# Altium schematic sheet sensors.SchDoc
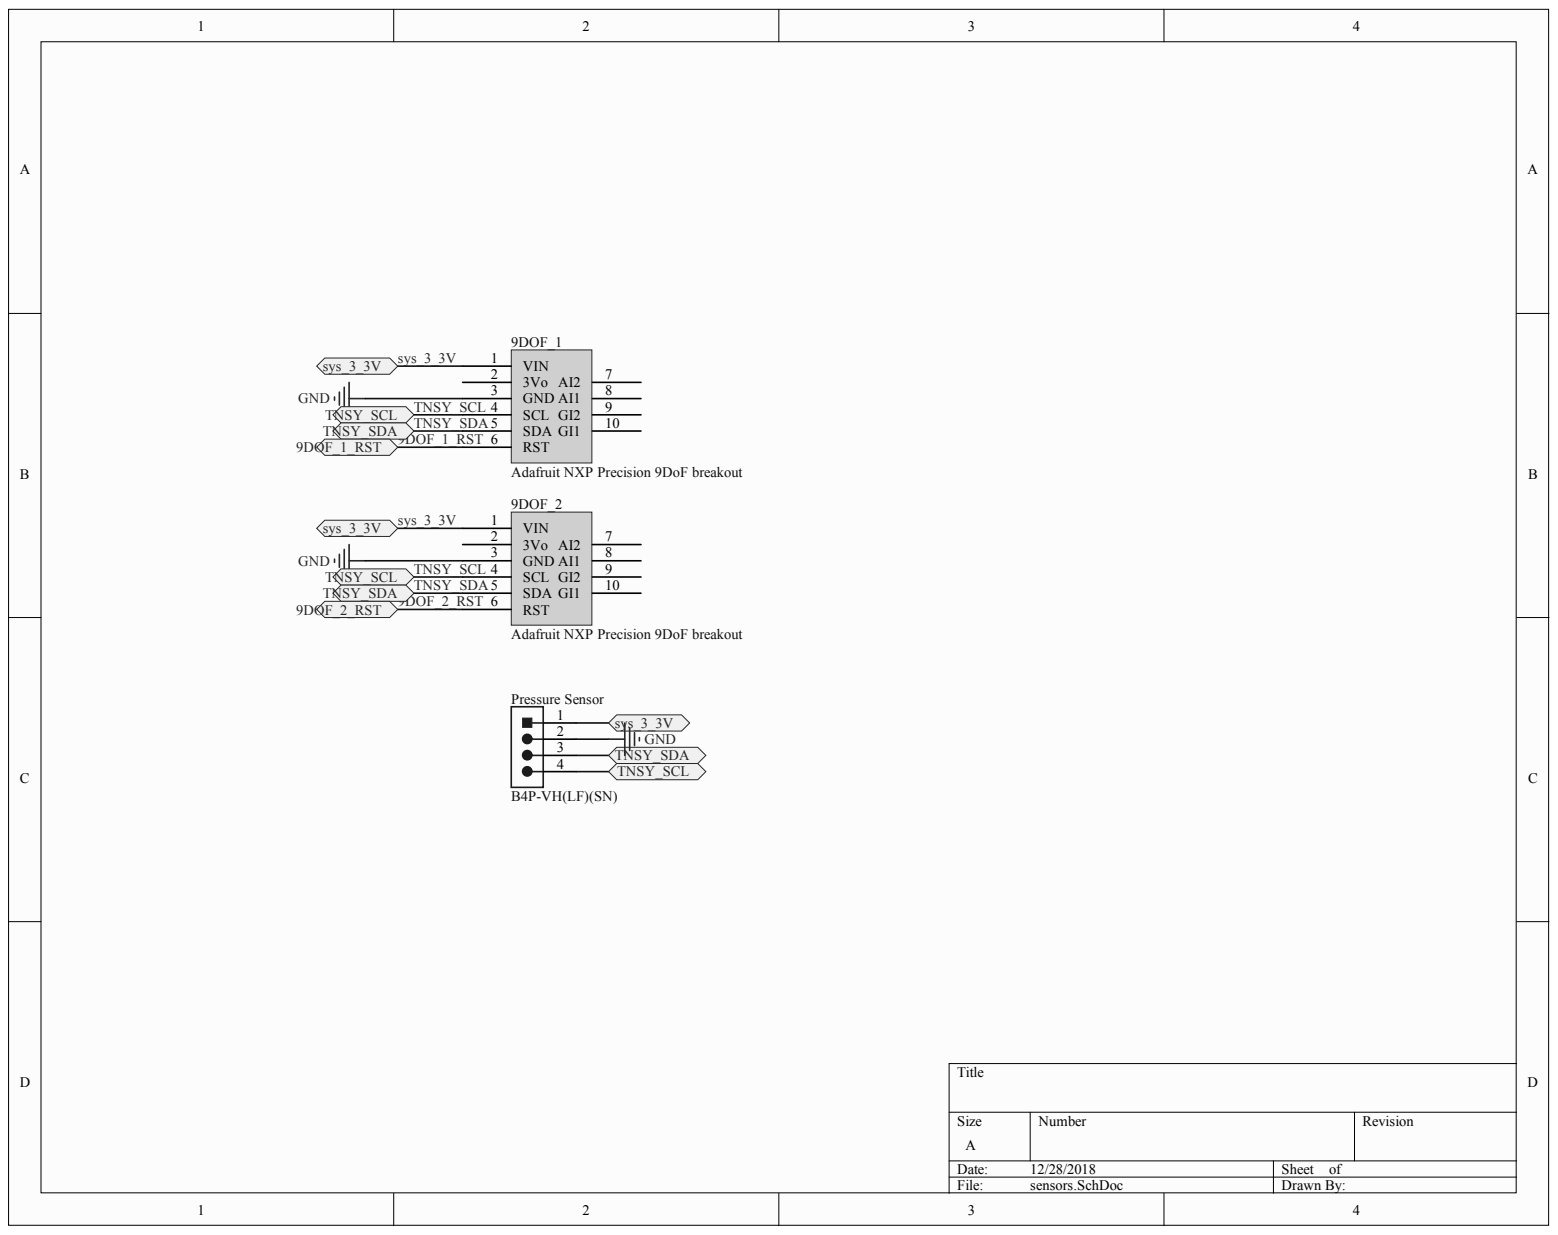
[diagram p0: sensors.SchDoc]

## Parts
9DOF_1  Adafruit NXP Precision 9DoF breakout  [PCB-qycbgvnmg1jf60fg22jb-1]
9DOF_2  Adafruit NXP Precision 9DoF breakout  [PCB-qycbgvnmg1jf60fg22jb-1]
Pressure_Sensor  B4P-VH(LF)(SN)  [PCB-643000-1]

## Ports
9DOF_1_RST, 9DOF_2_RST, SYS_3_3V, TNSY_SCL, TNSY_SDA

## Nets
GND: 9DOF_1.3(GND) 9DOF_2.3(GND) Pressure_Sensor.2
9DOF_1_RST: 9DOF_1.6(RST) ; port 9DOF_1_RST
9DOF_2_RST: 9DOF_2.6(RST) ; port 9DOF_2_RST
SYS_3_3V: 9DOF_1.1(VIN) 9DOF_2.1(VIN) Pressure_Sensor.1 ; port SYS_3_3V
TNSY_SCL: 9DOF_1.4(SCL) 9DOF_2.4(SCL) Pressure_Sensor.4 ; port TNSY_SCL
TNSY_SDA: 9DOF_1.5(SDA) 9DOF_2.5(SDA) Pressure_Sensor.3 ; port TNSY_SDA

Not connected: 9DOF_1 2(3Vo) 7(AI2) 8(AI1) 9(GI2) 10(GI1); 9DOF_2 2(3Vo) 7(AI2) 8(AI1) 9(GI2) 10(GI1)

## Library data of the parts
9DOF_1 9DOF_2 = CMP-4rmpjjg8h9uj8rll3hj9-1: Adafruit board breakout of the The FXOS8700 3-Axis accelerometer and magnetometer, and the FXAS21002 3-axis gyroscope.; Serial Protocol: I2C; Vin: 3 - 5V; Component Kind: Standard; CustomComponentID: 4rmpjjg8h9uj8rll3hj9; Library Name: FavoritesLibrary
Pressure_Sensor = CMP-643000-9: CONN HEADER VH TOP 4POS 3.96MM; Company: JST; Current Rating: 7.0 A; Gender: Male; Insulation Resistance: 1000000000.0 Ω; Lead-Free Status: Lead Free; Number of Contacts: 4; Pin Pitch: 0.00396 m; Voltage Rating (AC): 250.0 V; Component Kind: Standard; Contact Material: Brass; Contact Plating: Tin; Housing Color: Natural; Housing Material: Nylon; Library Name: CommunityVault; Manufacturer Part Number: B4P-VH(LF)(SN); Mounting Style: Through Hole; Number of Positions: 4; Number of Rows: 1; OctopartID: 3da8f4a1d32be26f; Octopart Url: https://octopart.com/b4p-vh%28lf%29%28sn%29-jst-248805; Packaging: Bulk; REACH SVHC Compliance: No SVHC; RoHS: Compliant; Size-Height: 0.0094 m; Size-Length: 0.01578 m; Size-Width: 0.0085 m
